# Altium schematic sheet sensor.SchDoc
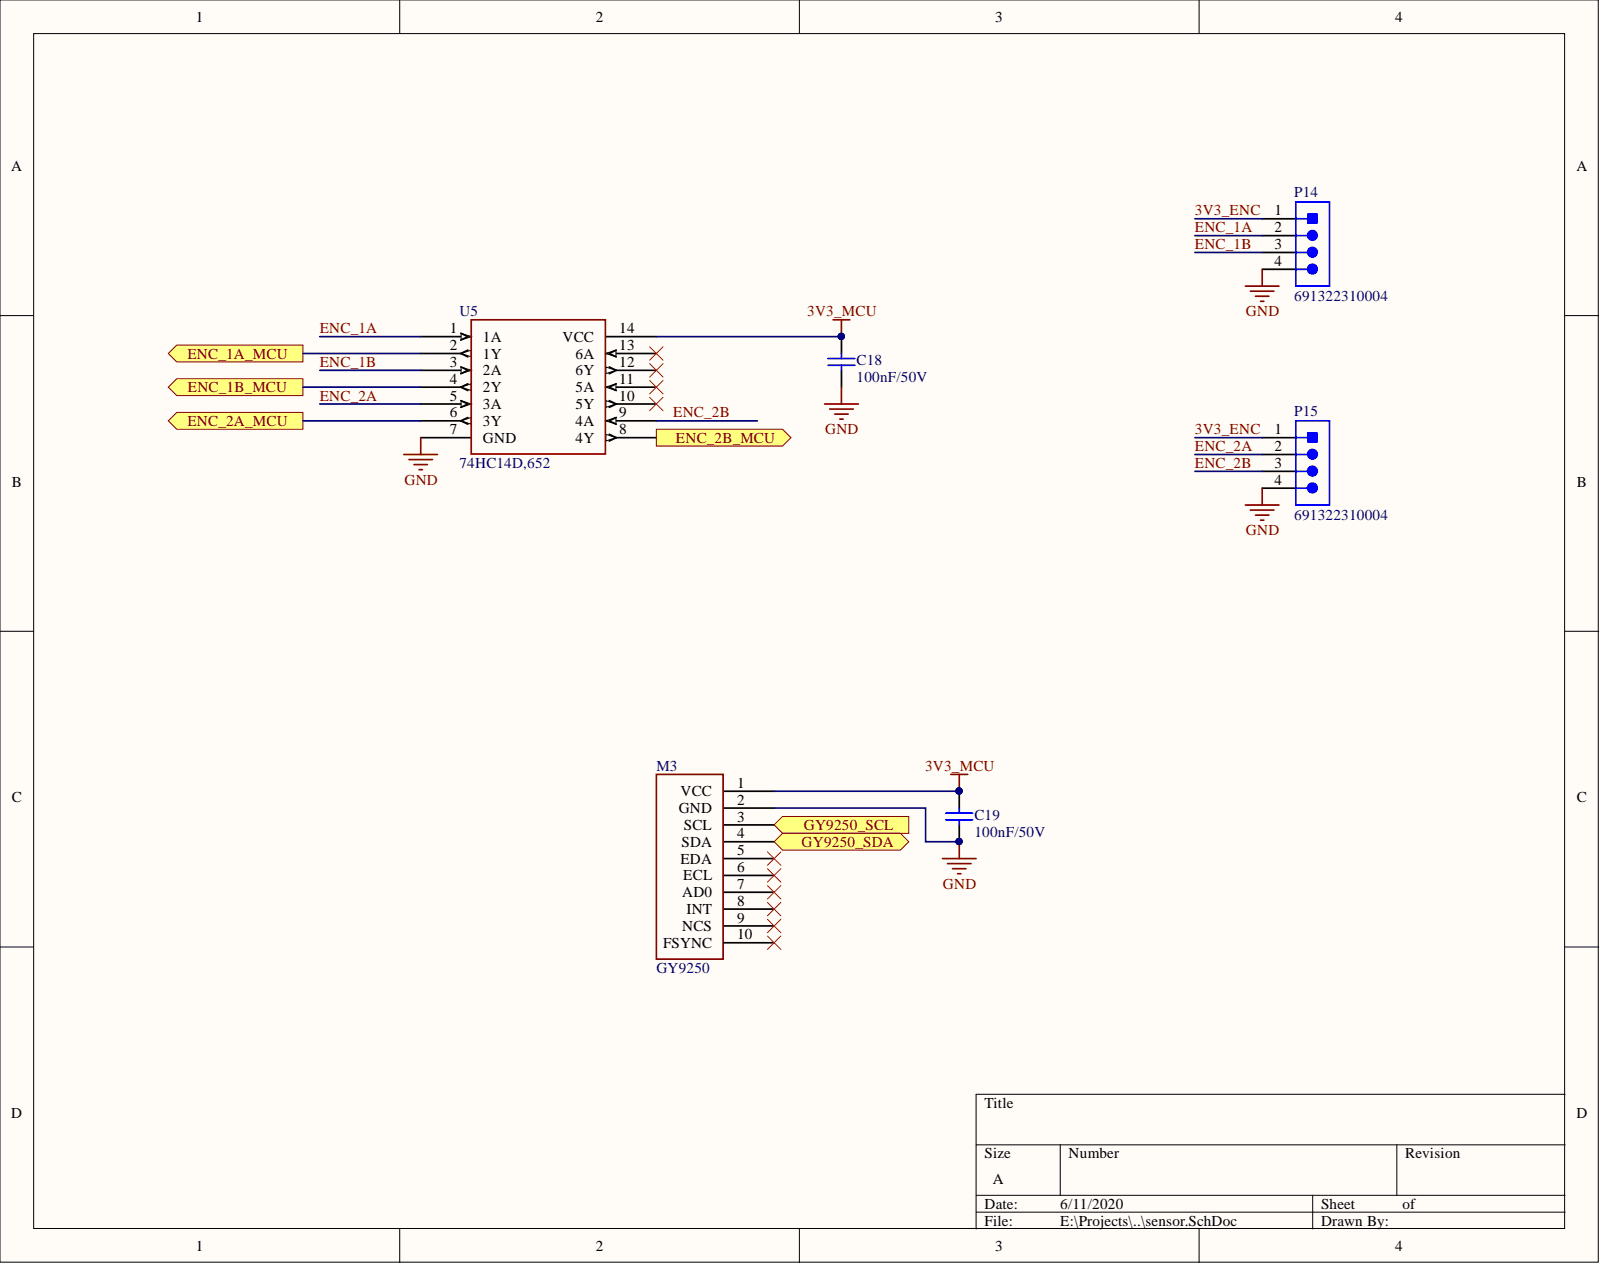
[diagram p0: sensor.SchDoc]

## Parts
C18  value 100nF/50V  [CAP-SM-0805]  (hidden comment: Cap-100nF-50V-0805)
C19  value 100nF/50V  [CAP-SM-0805]  (hidden comment: Cap-100nF-50V-0805)
M3  GY9250  [GY9250]
P14  691322310004  [691322310004]
P15  691322310004  [691322310004]
U5  74HC14D,652  [SOT108-1_NEX]

## Ports
ENC_1A_MCU, ENC_1B_MCU, ENC_2A_MCU, ENC_2B_MCU, GY9250_SCL, GY9250_SDA

## Nets
3V3_MCU: C18.2 C19.2 M3.1(VCC) U5.14(VCC)
GND: C18.1 C19.1 M3.2(GND) P14.4 P15.4 U5.7(GND)
3V3_ENC: P14.1 P15.1
ENC_1A: P14.2 U5.1(1A)
ENC_1A_MCU: U5.2(1Y) ; port ENC_1A_MCU
ENC_1B: P14.3 U5.3(2A)
ENC_1B_MCU: U5.4(2Y) ; port ENC_1B_MCU
ENC_2A: P15.2 U5.5(3A)
ENC_2A_MCU: U5.6(3Y) ; port ENC_2A_MCU
ENC_2B: P15.3 U5.9(4A)
ENC_2B_MCU: U5.8(4Y) ; port ENC_2B_MCU
GY9250_SCL: M3.3(SCL) ; port GY9250_SCL
GY9250_SDA: M3.4(SDA) ; port GY9250_SDA

Not connected: M3 5(EDA) 6(ECL) 7(AD0) 8(INT) 9(NCS) 10(FSYNC); U5 10(5Y) 11(5A) 12(6Y) 13(6A)

## Library data of the parts
C18 C19 = Cap-100nF-50V-0805: CAP CER 0.1UF 50V Y5V 0805; Manufacturer: Samsung Electro-Mechanics; Manufacturer Part Number: CL21F104ZBCNNNC; Component Kind: Standard; Library Name: Capacitor.SchLib; Local Supplier: thegioiic; Local Supplier Link: https://thegioiic.com/products/tu-100nf-0-1uf-50v-0805; Supplier 1: Digikey; Supplier 1 Link: https://www.digikey.com/product-detail/en/samsung-electro-mechanics/CL21F104ZBCNNNC/1276-1007-1-ND/3889093; Supplier 1 Part Number: 1276-1007-1-ND
P14 P15 = 691322310004: WR-TBL Serie 322 Horizontal PCB Header, Pluggable, pitch 3.81mm, 4p; Compliance: RoHS; Modularity: No; Pin Number: 4; Rated Current [UL]: 10A; Working Voltage [UL]: 300VAC; Application: Pluggable; Component Kind: Standard; ComponentLink1Description: Manufacturer; ComponentLink1URL: http://www.we-online.de; ComponentLink2Description: Datasheet; ComponentLink2URL: https://katalog.we-online.de/em/datasheet/691322310004.pdf; Library Name: 691322110004_Altium_WR-TBL_rev19a.IntLib; Mounting Technology: THT; Operating Temperature: -40 to +105degC; Packaging: Box; Part Number: 691322310004; PCB/Cable/Panel: PCB; Pitch: 3.81mm; Published Date: October 2019; Type: Horizontal; Publisher: Wurth Elektronik
U5 = 74HC14D,652: No Description Available; SourceLibrary: Nexperia_2018-05-2018; Vendor: Nexperia; Component Kind: Standard; Manufacturer_Part_Number: 74HC14D,652; RefDes: RefDes; Type: DEV; Value: Value; Copyright: Copyright (C) 2016 Accelerated Designs. All rights reserved; Library Name: PIFWRobot.SchLib
M3 = GY9250: Component Kind: Standard; Library Name: PIFWRobot.SchLib
